# Altium schematic sheet SDADC.SchDoc(SDADC)
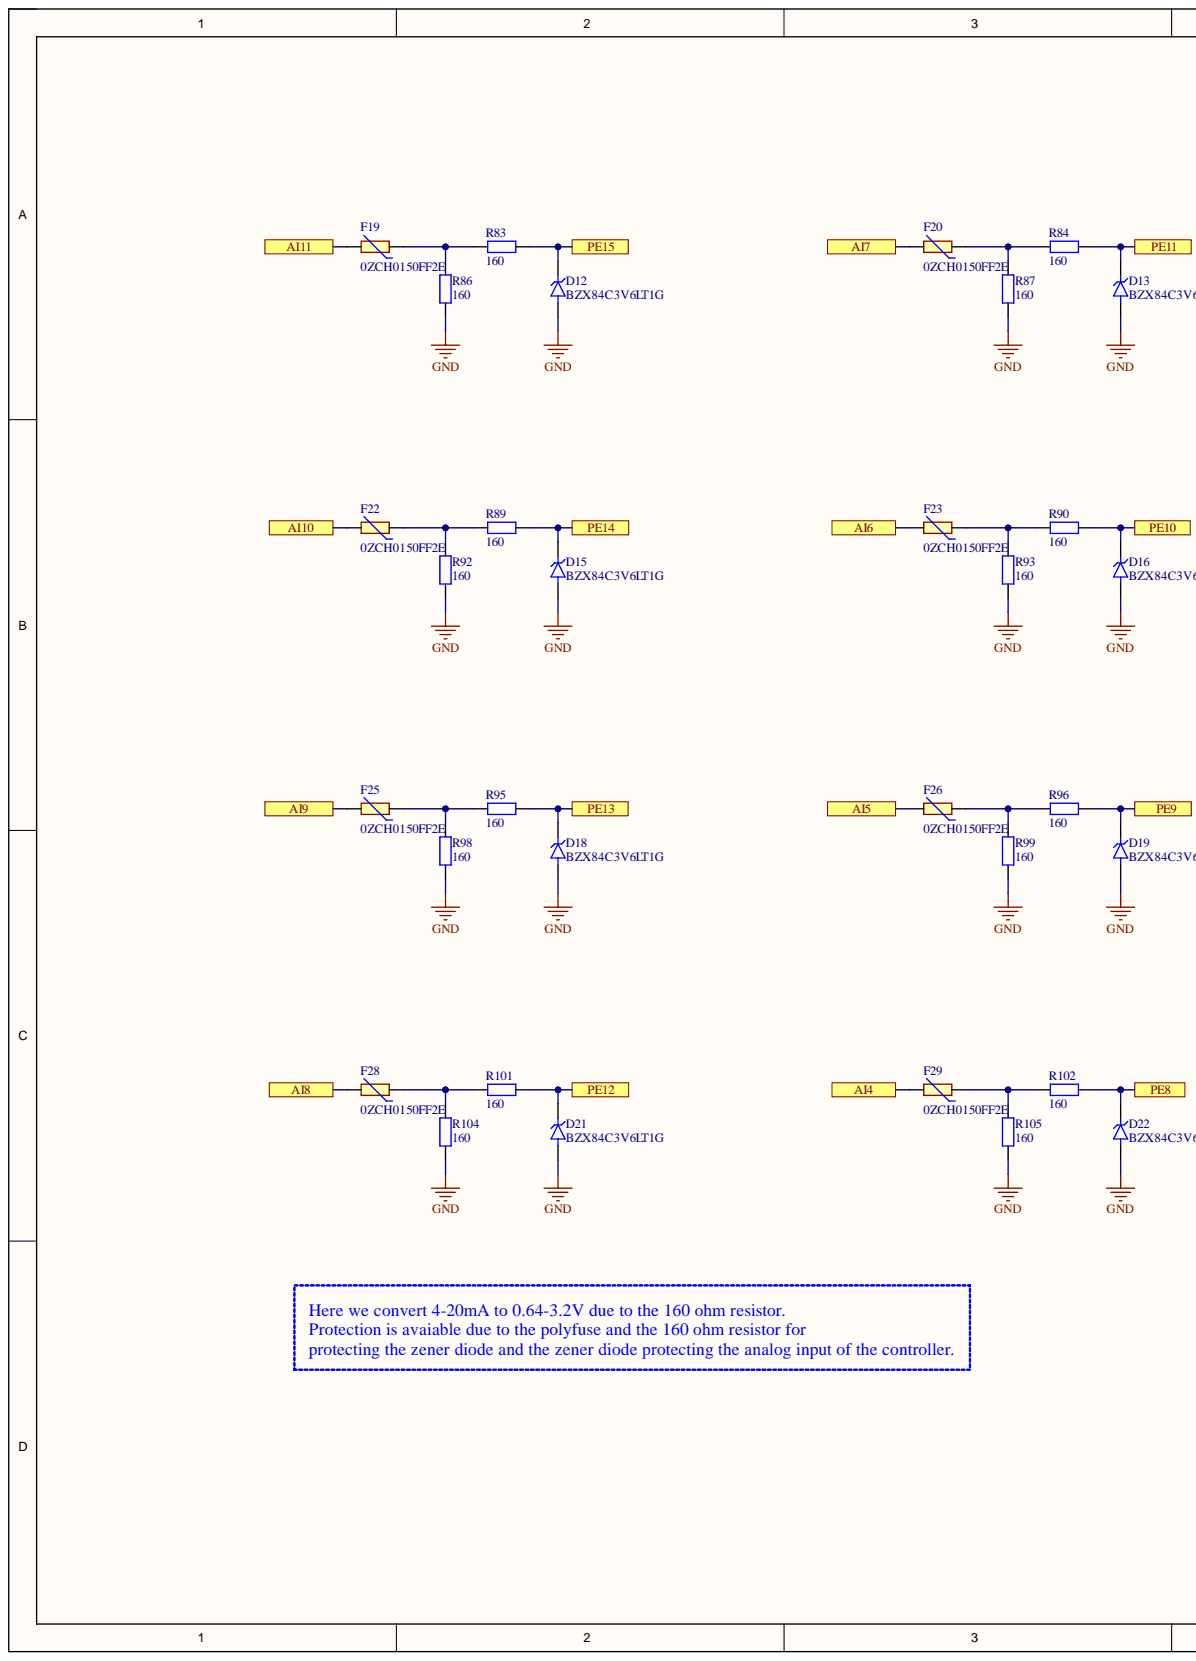
[diagram p0: SDADC.SchDoc, left]
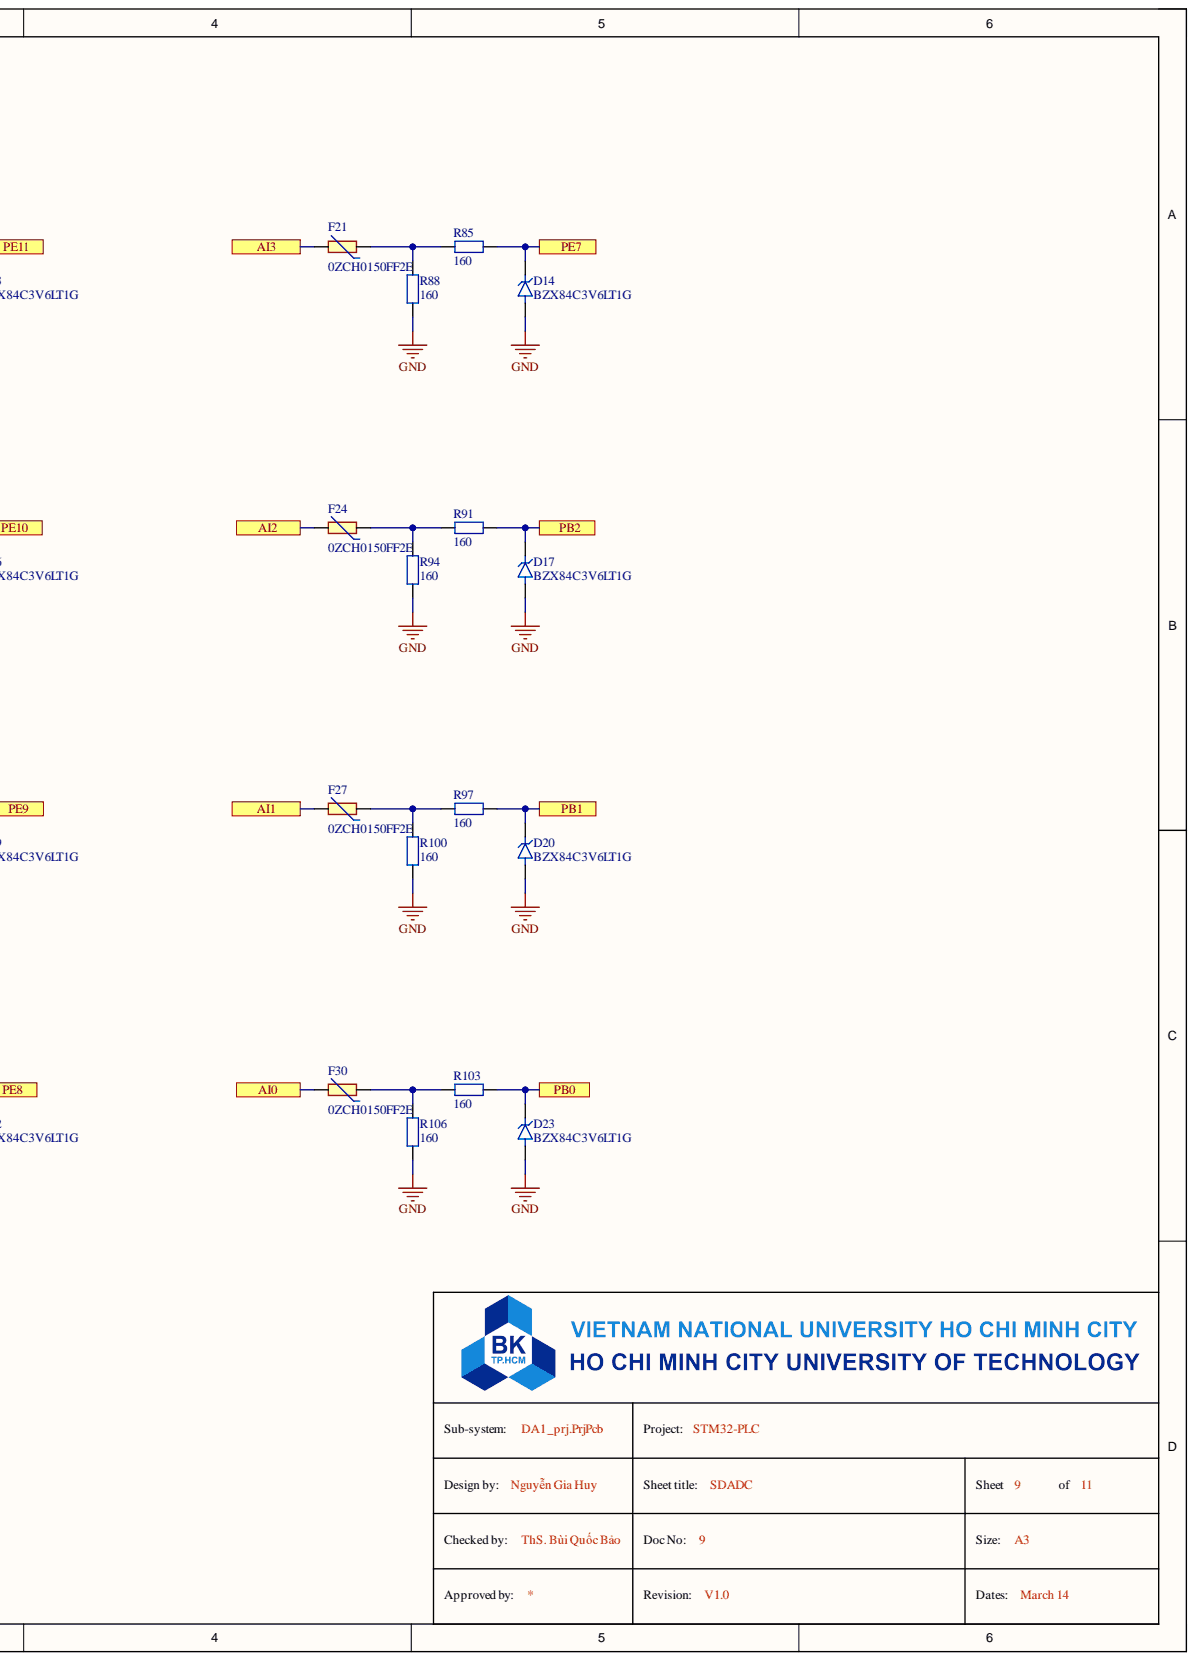
[diagram p1: SDADC.SchDoc, right]

## Parts
D12  BZX84C3V6LT1G  [SOT95P240X111-3N]
D13  BZX84C3V6LT1G  [SOT95P240X111-3N]
D14  BZX84C3V6LT1G  [SOT95P240X111-3N]
D15  BZX84C3V6LT1G  [SOT95P240X111-3N]
D16  BZX84C3V6LT1G  [SOT95P240X111-3N]
D17  BZX84C3V6LT1G  [SOT95P240X111-3N]
D18  BZX84C3V6LT1G  [SOT95P240X111-3N]
D19  BZX84C3V6LT1G  [SOT95P240X111-3N]
D20  BZX84C3V6LT1G  [SOT95P240X111-3N]
D21  BZX84C3V6LT1G  [SOT95P240X111-3N]
D22  BZX84C3V6LT1G  [SOT95P240X111-3N]
D23  BZX84C3V6LT1G  [SOT95P240X111-3N]
F19  0ZCH0150FF2E  [FUSC3225X90N]
F20  0ZCH0150FF2E  [FUSC3225X90N]
F21  0ZCH0150FF2E  [FUSC3225X90N]
F22  0ZCH0150FF2E  [FUSC3225X90N]
F23  0ZCH0150FF2E  [FUSC3225X90N]
F24  0ZCH0150FF2E  [FUSC3225X90N]
F25  0ZCH0150FF2E  [FUSC3225X90N]
F26  0ZCH0150FF2E  [FUSC3225X90N]
F27  0ZCH0150FF2E  [FUSC3225X90N]
F28  0ZCH0150FF2E  [FUSC3225X90N]
F29  0ZCH0150FF2E  [FUSC3225X90N]
F30  0ZCH0150FF2E  [FUSC3225X90N]
R83  160  [tro smd 0603]
R84  160  [tro smd 0603]
R85  160  [tro smd 0603]
R86  160  [tro smd 0603]
R87  160  [tro smd 0603]
R88  160  [tro smd 0603]
R89  160  [tro smd 0603]
R90  160  [tro smd 0603]
R91  160  [tro smd 0603]
R92  160  [tro smd 0603]
R93  160  [tro smd 0603]
R94  160  [tro smd 0603]
R95  160  [tro smd 0603]
R96  160  [tro smd 0603]
R97  160  [tro smd 0603]
R98  160  [tro smd 0603]
R99  160  [tro smd 0603]
R100  160  [tro smd 0603]
R101  160  [tro smd 0603]
R102  160  [tro smd 0603]
R103  160  [tro smd 0603]
R104  160  [tro smd 0603]
R105  160  [tro smd 0603]
R106  160  [tro smd 0603]

## Ports
AI0, AI1, AI2, AI3, AI4, AI5, AI6, AI7, AI8, AI9, AI10, AI11, PB0, PB1, PB2, PE7, PE8, PE9, PE10, PE11, PE12, PE13, PE14, PE15

## Nets
GND: D12.1 D13.1 D14.1 D15.1 D16.1 D17.1 D18.1 D19.1 D20.1 D21.1 D22.1 D23.1 R86.1 R87.1 R88.1 R92.1 R93.1 R94.1 R98.1 R99.1 R100.1 R104.1 R105.1 R106.1
AI0: F30.2 ; port AI0
AI1: F27.2 ; port AI1
AI2: F24.2 ; port AI2
AI3: F21.2 ; port AI3
AI4: F29.2 ; port AI4
AI5: F26.2 ; port AI5
AI6: F23.2 ; port AI6
AI7: F20.2 ; port AI7
AI8: F28.2 ; port AI8
AI9: F25.2 ; port AI9
AI10: F22.2 ; port AI10
AI11: F19.2 ; port AI11
PB0: D23.3 R103.2 ; port PB0
PB1: D20.3 R97.2 ; port PB1
PB2: D17.3 R91.2 ; port PB2
PE7: D14.3 R85.2 ; port PE7
PE8: D22.3 R102.2 ; port PE8
PE9: D19.3 R96.2 ; port PE9
PE10: D16.3 R90.2 ; port PE10
PE11: D13.3 R84.2 ; port PE11
PE12: D21.3 R101.2 ; port PE12
PE13: D18.3 R95.2 ; port PE13
PE14: D15.3 R89.2 ; port PE14
PE15: D12.3 R83.2 ; port PE15
NetF19_1: F19.1 R83.1 R86.2
NetF20_1: F20.1 R84.1 R87.2
NetF21_1: F21.1 R85.1 R88.2
NetF22_1: F22.1 R89.1 R92.2
NetF23_1: F23.1 R90.1 R93.2
NetF24_1: F24.1 R91.1 R94.2
NetF25_1: F25.1 R95.1 R98.2
NetF26_1: F26.1 R96.1 R99.2
NetF27_1: F27.1 R97.1 R100.2
NetF28_1: F28.1 R101.1 R104.2
NetF29_1: F29.1 R102.1 R105.2
NetF30_1: F30.1 R103.1 R106.2

## Library data of the parts
F19-F30 = 0ZCH0150FF2E: 1210 SMT PPTC | 1.50 Ih | 6V; MF: Bel Fuse; Check_prices: https://www.snapeda.com/parts/0ZCH0150FF2E/Bel+Circuit+Protection/view-part/?ref=eda; Component Kind: Standard; Library Name: 0ZCH0150FF2E.IntLib; MP: 0ZCH0150FF2E; Package: Package; SnapEDA_Link: https://www.snapeda.com/parts/0ZCH0150FF2E/Bel+Circuit+Protection/view-part/?ref=snap
R83-R106 = Tro 0603 1% 10k: RES; Chung_Loai: Dán; Don_Vi: Con; Ma_Hang: MH006333; So_Chan: 2; Ten_Linh_Kien: Trở 0603 1% 10k; Component Kind: Standard; Library Name: AUSB.SchLib
D12-D23 = BZX84C3V6LT1G: Availability: Unavailable; Component Kind: Standard; Library Name: BZX84C3V6LT1G.IntLib; MP: BZX84C3V6LT1G; Package: None; Price: None
